# Altium schematic sheet 01_FPGA_Power.SchDoc
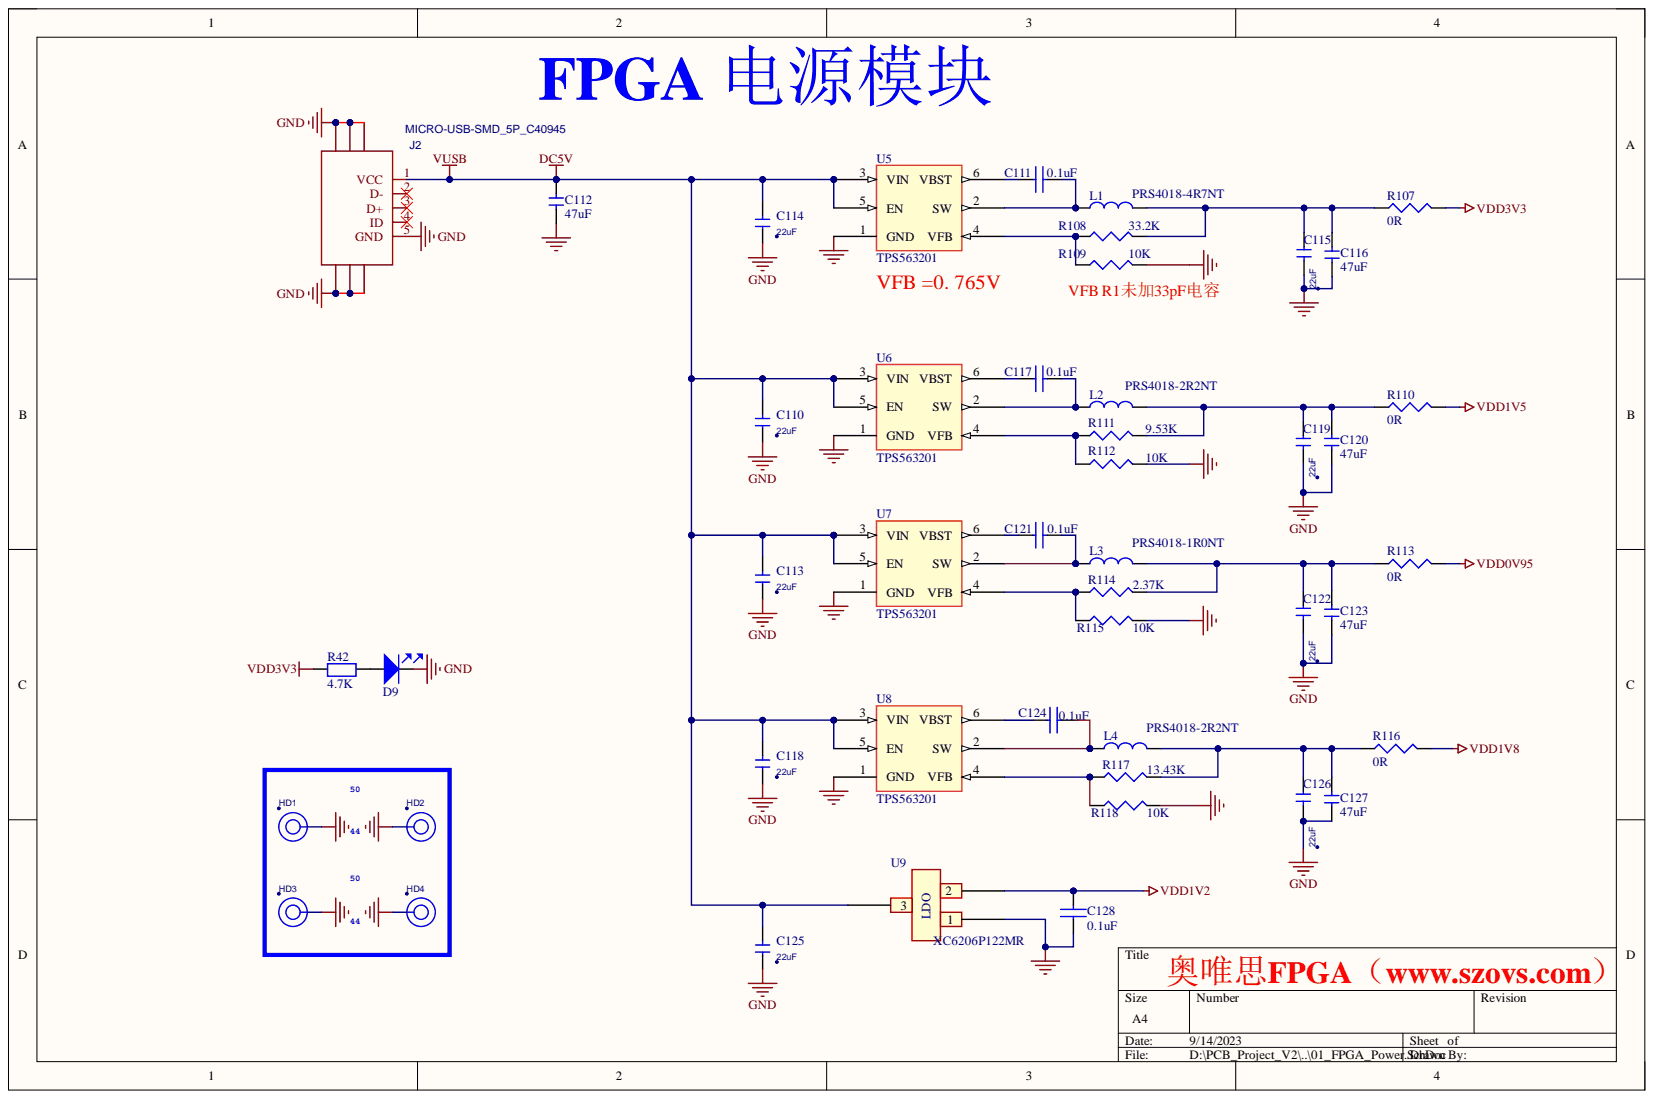
[diagram p0: 01_FPGA_Power.SchDoc]

## Parts
C110  22uF  [R0603]
C111  0.1uF  [R0402]
C112  47uF  [R0805]
C113  22uF  [R0603]
C114  22uF  [R0603]
C115  22uF  [R0603]
C116  47uF  [R0805]
C117  0.1uF  [R0402]
C118  22uF  [R0603]
C119  22uF  [R0603]
C120  47uF  [R0805]
C121  0.1uF  [R0402]
C122  22uF  [R0603]
C123  47uF  [R0805]
C124  0.1uF  [R0402]
C125  22uF  [R0603]
C126  22uF  [R0603]
C127  47uF  [R0805]
C128  0.1uF  [R0402]
D9  LED红  [D0603]  (value not drawn)
HD1  nc  [DW5532]  (value not drawn)
HD2  nc  [DW5532]  (value not drawn)
HD3  nc  [DW5532]  (value not drawn)
HD4  nc  [DW5532]  (value not drawn)
J2  MICRO-USB-SMD_5P_C40945  [MICRO-USB-SMD_5P_C40945]
L1  PRS4018-4R7NT  [PRS4018]
L2  PRS4018-2R2NT  [PRS4018]
L3  PRS4018-1R0NT  [PRS4018]
L4  PRS4018-2R2NT  [PRS4018]
R42  4.7K  [R0402]
R107  0R  [R0603]
R108  33.2K  [R0402]
R109  10K  [R0402]
R110  0R  [R0603]
R111  9.53K  [R0402]
R112  10K  [R0402]
R113  0R  [R0603]
R114  2.37K  [R0402]
R115  10K  [R0402]
R116  0R  [R0603]
R117  13.43K  [R0402]
R118  10K  [R0402]
U5  TPS563201  [SOT23-6]
U6  TPS563201  [SOT23-6]
U7  TPS563201  [SOT23-6]
U8  TPS563201  [SOT23-6]
U9  XC6206P122MR  [SOT23-3]

## Nets
DC5V: C110.2 C112.2 C113.2 C114.2 C118.2 C125.2 J2.1(VCC) U5.3(VIN) U5.5(EN) U6.3(VIN) U6.5(EN) U7.3(VIN) U7.5(EN) U8.3(VIN) U8.5(EN) U9.3
GND: C110.1 C112.1 C113.1 C114.1 C115.2 C116.2 C118.1 C119.2 C120.2 C122.2 C123.2 C125.1 C126.2 C127.2 C128.1 D9.2 HD1.HD HD2.HD HD3.HD HD4.HD J2.5(GND) J2.6 J2.7 J2.8 J2.9 J2.10 J2.11 R109.2 R112.2 R115.2 R118.2 U5.1(GND) U6.1(GND) U7.1(GND) U8.1(GND) U9.1
VDD0V95: R113.2
VDD1V2: C128.2 U9.2
VDD1V5: R110.2
VDD1V8: R116.2
VDD3V3: R42.1 R107.2
NetC111_1: C111.1 U5.6(VBST)
NetC111_2: C111.2 L1.1 U5.2(SW)
NetC115_1: C115.1 C116.1 L1.2 R107.1 R108.2
NetC117_1: C117.1 U6.6(VBST)
NetC117_2: C117.2 L2.1 U6.2(SW)
NetC119_1: C119.1 C120.1 L2.2 R110.1 R111.2
NetC121_1: C121.1 U7.6(VBST)
NetC121_2: C121.2 L3.1 U7.2(SW)
NetC122_1: C122.1 C123.1 L3.2 R113.1 R114.2
NetC124_1: C124.1 U8.6(VBST)
NetC124_2: C124.2 L4.1 U8.2(SW)
NetC126_1: C126.1 C127.1 L4.2 R116.1 R117.2
NetD9_1: D9.1 R42.2
NetR108_1: R108.1 R109.1 U5.4(VFB)
NetR111_1: R111.1 R112.1 U6.4(VFB)
NetR114_1: R114.1 R115.1 U7.4(VFB)
NetR117_1: R117.1 R118.1 U8.4(VFB)

Not connected: J2 2(D-) 3(D+) 4(ID)

## Library data of the parts
C110 C113-C115 C118 C119 C122 C125 C126 = C0603: Component Kind: Standard; Library Name: 162_Library.SchLib
C111 C117 C121 C124 C128 = C0402: Component Kind: Standard; Library Name: 162_Library.SchLib
C112 C116 C120 C123 C127 = C0805: Component Kind: Standard; Library Name: 162_Library.SchLib
D9 = LED: LED 发光二极管; Component Kind: Standard; Library Name: Crazy_PCB.SchLib
HD1-HD4 = 1hole: Component Kind: Standard
J2 = MICRO-USB-SMD_5P_C40945: BOM_Manufacturer: ValuePro; BOM_Manufacturer Part: micro 5PFour feet full of sticks without holes0.2Half gold tin; BOM_JLCPCB Part Class: Extended Part; BOM_Supplier: LCSC; BOM_Supplier Part: C40945; Component Kind: Standard; Library Name: Ti60_F225_Core.SCHLIB; spicePre: U; spiceSymbolName: micro 5PFour feet full of sticks without holes0.2Half gold tin
L1-L4 = Inductor: Component Kind: Standard; Library Name: Crazy_PCB.SchLib
R42 = RES: Component Kind: Standard; Library Name: Crazy_PCB.SchLib
R107-R118 = R: Component Kind: Standard; Library Name: 162_Library.SchLib
U5-U8 = TPS563201: Component Kind: Standard; Library Name: 162_Library.SchLib
U9 = XC6206P122MR: Component Kind: Standard; Library Name: 162_Library.SchLib
